# Altium schematic sheet SteppperController.SchDoc(SteppperController)
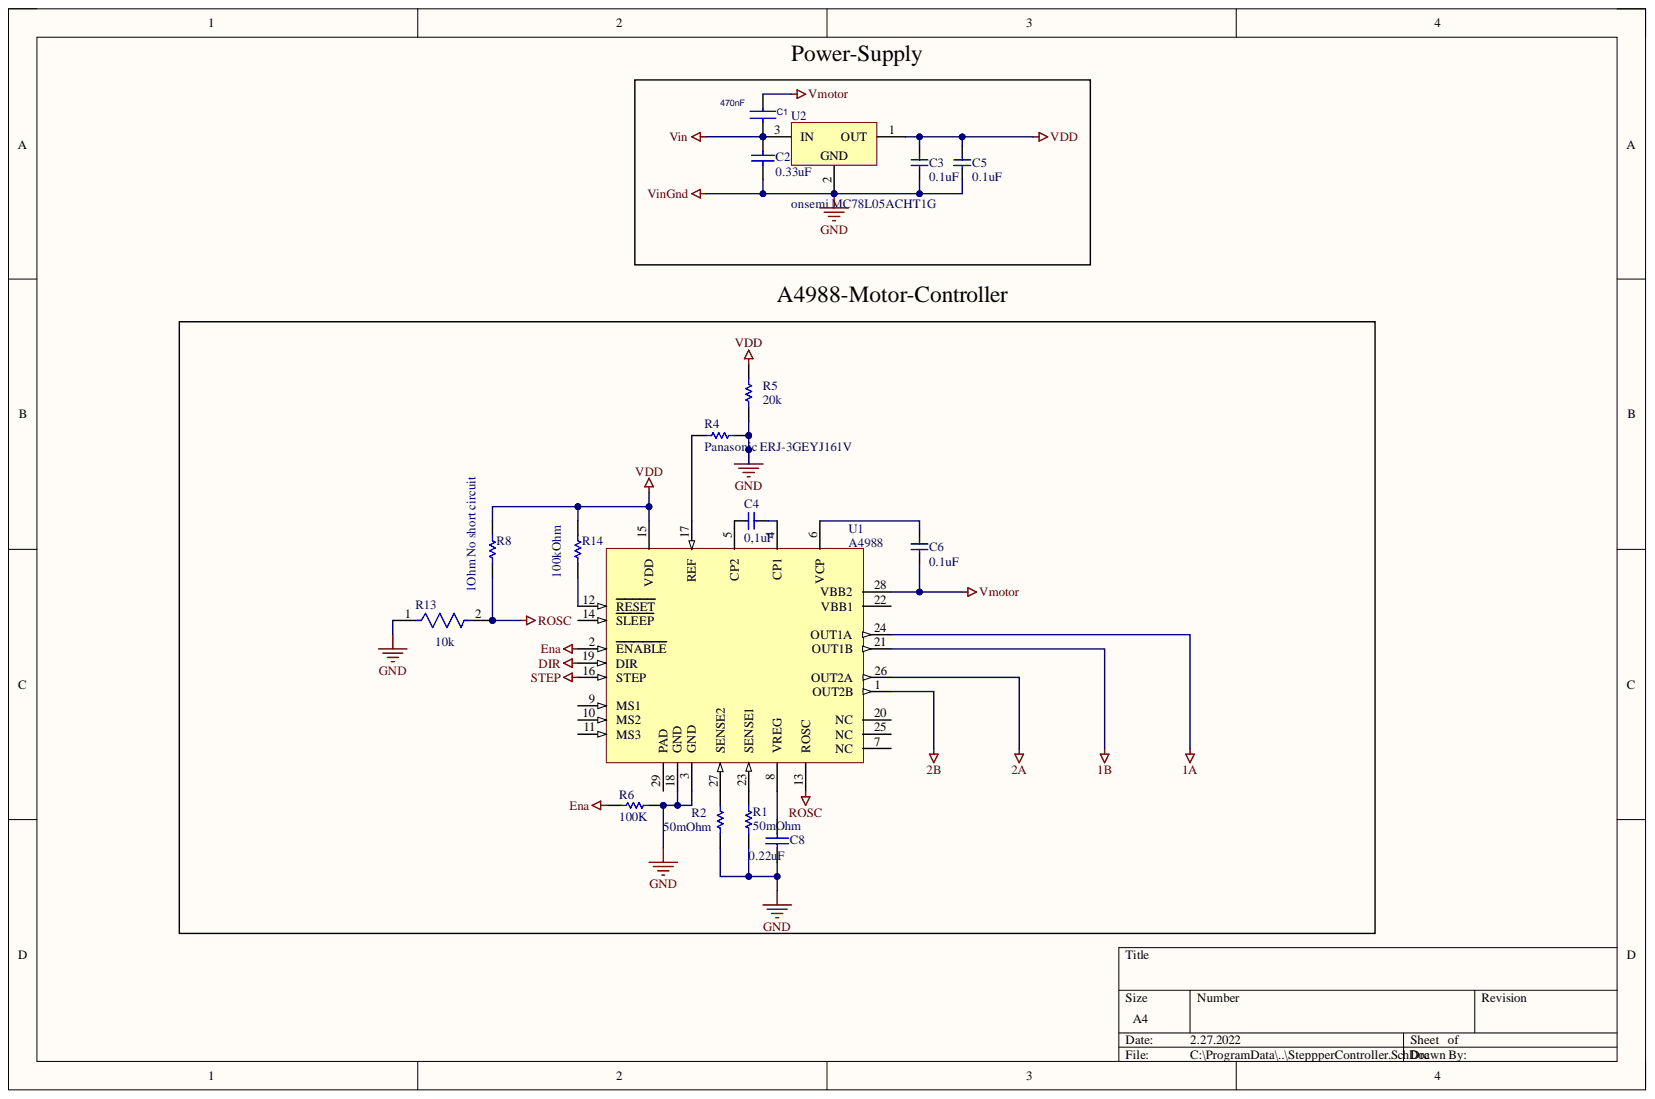
[diagram p0: SteppperController.SchDoc]

## Parts
C1  470nF  [PCB-ab16gx9rkhzdcptx7kd3-1]
C2  0.33uF  [PCB-w610zufupuummbghd1in-1]
C3  0.1uF  [PCB-lcc7kuvv5qjd2xw4yurg-1]
C4  0,1uF  [PCB-lcc7kuvv5qjd2xw4yurg-1]
C5  0.1uF  [PCB-lcc7kuvv5qjd2xw4yurg-1]
C6  0.1uF  [PCB-lcc7kuvv5qjd2xw4yurg-1]
C8  0.22uF  [PCB-pms7qjbv2zfto0v29362-1]
R1  50mOhm  [PCB-h38ewjcsmg51leo397rp-1]
R2  50mOhm  [PCB-h38ewjcsmg51leo397rp-1]
R4  Panasonic ERJ-3GEYJ161V  Manufacturer Part Number: ERJ-3GEYJ161V  [RESC1608X55X30NL15T15]
R5  20k  [RESC2013X55X40LL15T20]
R6  100K  [PCB-n97kkldbbf5rklmnt71m-1]
R8  1Ohm No short circuit  [RESC1005X40X25ML05T05]
R13  10k  [FP-CRCW0402-e3-IPC_B]
R14  100kOhm  [RESC2013X60X35ML10T20]
U1  A4988  [ALEG-ET-28_V]
U2  onsemi MC78L05ACHT1G  Manufacturer Part Number: MC78L05ACHT1G  [NXP-SOT89_A_M]

## Nets
1A: U1.24(OUT1A)
1B: U1.21(OUT1B)
2A: U1.26(OUT2A)
2B: U1.1(OUT2B)
DIR: U1.19(DIR)
Ena: R6.2 U1.2(ENABLE)
GND: C2.1 C3.1 C5.1 C8.1 R1.1 R2.1 R4.2 R5.1 R6.1 R13.1 U1.3(GND) U1.18(GND) U2.2
ROSC: R8.1 R13.2 U1.13(ROSC)
STEP: U1.16(STEP)
VDD: C3.2 C5.2 R5.2 R8.2 R14.2 U1.15(VDD) U2.1
Vin: C1.2 C2.2 U2.3
Vmotor: C1.1 C6.1 U1.28(VBB2)
NetC4_1: C4.1 U1.5(CP2)
NetC4_2: C4.2 U1.4(CP1)
NetC6_2: C6.2 U1.6(VCP)
NetC8_2: C8.2 U1.8(VREG)
NetR1_2: R1.2 U1.23(SENSE1)
NetR2_2: R2.2 U1.27(SENSE2)
NetR4_1: R4.1 U1.17(REF)
NetR14_1: R14.1 U1.12(RESET)

Not connected: U1 7(NC) 9(MS1) 10(MS2) 11(MS3) 14(SLEEP) 20(NC) 22(VBB1) 25(NC) 29(PAD)

## Library data of the parts
C1 = CMP-c29e0abbad7d1d59-2: Cap Ceramic 0.47uF 10V X5R 20% SMD 0402 85°C T/R; Manufacturer Part Number: 0402ZD474MAT2A; Voltage Rating (DC): 10.0 V; Capacitance: 470 nF; Capacitance Tolerance: ±20%; Case/Package: 0402; Component Kind: Standard; Dielectric Characteristic: X5R; Library Name: My Personal Space; Octopart URL: http://octopart.com/0402zd474mat2a-avx-19876485; OctopartID: c29e0abbad7d1d59; Packaging: Reel; RoHS: Compliant
C2 = CMP-6acba34cbf0cb50c-1: CL31 Series 0.33 uF 50 V ±10 % Tolerance X7R SMT Multilayer Ceramic Capacitor; Manufacturer Part Number: CL31B334KBFNNNE; Capacitance: 330 nF; Capacitance Tolerance: ±10%; Lead-Free Status: Lead Free; Voltage Rating (DC): 50.0 V; Case/Package: 1206; Component Kind: Standard; Dielectric Characteristic: X7R; Library Name: My Personal Space; Mounting Style: Surface Mount; Octopart URL: https://octopart.com/cl31b334kbfnnne-samsung-9302177; OctopartID: 6acba34cbf0cb50c; RoHS: Compliant
C3-C6 = CMP-915a59dc44ec4e9d-4: C Series 1206 0.1 uF 50 V ±5 % Tolerance C0G SMT Multilayer Ceramic Capacitor; Component Kind: Standard; Library Name: My Personal Space
C8 = CMP-3551434-4: Multilayer Ceramic Capacitors MLCC - SMD/SMT 50volts .22uF 5% X7R 7inch reel; Manufacturer Part Number: 08055C224JAT2A; Capacitance: 2.2e-07 F; Lead-Free Status: Lead Free; Voltage Rating (DC): 50.0 V; Capacitance Tolerance: ±5%; Case/Package: 0805; Component Kind: Standard; Dielectric Characteristic: X7R; Library Name: My Personal Space; Mounting Style: Surface Mount; Number of Pins: 2; Octopart Url: https://octopart.com/08055c224jat2a-avx+interconnect+%2F+elco-8665279; OctopartID: 61bba8357c62be7c; RoHS: Compliant
R1 R2 = CMP-2fd8e4750972f01f-1: Thick Film Surface Mnt Resistor 2512 Case 50MOhm +/-1% 1W +/-100ppm/degC; Manufacturer Part Number: CRHV2512AF50M0FKET; Component Kind: Standard; Library Name: My Personal Space; Octopart URL: http://octopart.com/crhv2512af50m0fket-vishay-44499916; OctopartID: 2fd8e4750972f01f; Packaging: Reel
R4 = CMP-3677770-2: Thick Film Resistors - SMD 0603 160ohms 5% Tol; Lead-Free Status: Lead Free; Power Rating: 0.1 W; Resistance: 160.0 Ω; Voltage Rating (DC): 75.0 V; Case/Package: 0603; Component Kind: Standard; Library Name: My Personal Space; Lifecycle Status: Not Listed by Manufacturer; Mounting Style: Surface Mount; Octopart Url: https://octopart.com/erj-3geyj161v-panasonic-39786526; OctopartID: 556f9e3a68178aa8; Packaging: Cut Tape (CT); REACH SVHC Compliance: No SVHC; Resistance Tolerance: ±5%; RoHS: Compliant; Size-Height: 0.00045 m; Size-Length: 0.0016 m; Size-Width: 0.0008 m
R5 = CMP-15323555-2: Manufacturer Part Number: TNPW080520K0BEEA; Lead-Free Status: Lead Free; Power Rating: 0.125 W; Resistance: 20000.0 Ω; Resistance Tolerance: ±0.1%; Voltage Rating (DC): 100.0 V; Case/Package: 0805; Component Kind: Standard; Library Name: My Personal Space; Number of Pins: 2; Octopart Url: https://octopart.com/tnpw080520k0beea-vishay-46507859; OctopartID: 596b92a4db5e86ac; RoHS: Compliant
R6 = CMP-86ed4a8b7141592a-1: Res General Purpose Thick Film 0402 100k Ohm 1% 1/16W ±100ppm/°C Molded Paper T/R; Manufacturer Part Number: CRGCQ0402F100K; Power Rating: 63.0 mW; Resistance: 100 kΩ; Temperature Coefficient: -100 ppm/°C to 100 ppm/°C; Voltage Rating (DC): 50.0 V; Case/Package: 0402; Component Kind: Standard; Library Name: My Personal Space; Lifecycle Status: Not Listed by Manufacturer; Octopart URL: https://octopart.com/crgcq0402f100k-te+connectivity-91017930; OctopartID: 86ed4a8b7141592a; Packaging: Tape & Reel (TR); Resistance Tolerance: ±1%; RoHS: Compliant
R8 = CMP-2002-08342-1: Manufacturer: Vishay Dale; Part Number: CRCW04021R00FKED; Component Kind: Standard; Library Name: Altium Content Vault
R13 = CMP-2002-08135-2: RES SMD 10K OHM 1% 1/16W 0402; Max Power Dissipation: 63mW; Resistance: 10kR; Temperature Coefficient: 100ppm/°C; Voltage Rating: 50V; Case Code (Imperial): 0402; Case Code (Metric): 1005; Case/Package: 0402; Component Kind: Standard; Composition: Thick Film; Contact Plating: Tin; Depth: 0.5mm; Features: Automotive AEC-Q200; Height: 0.35mm; Lead Free: Lead Free; Length: 1mm; Library Name: Altium Content Vault; Max Operating Temperature: 155°C; Military Standard: Not; Min Operating Temperature: -55°C; Mount: Surface Mount; Number of Pins: 2; Number of Terminations: 2; Package Quantity: 10; Packaging: Tape and Reel; Power Rating: 63mW; Radiation Hardening: No; Termination: SMD/SMT; Tolerance: 1%; Voltage Rating (DC): 50V; Weight: 2.3E-05oz; Width: 0.02inch
R14 = CMP-2100-03668-1: Chip Resistor, 100 KOhm, +/- 1%, 0.125 W, -55 to 155 degC, 0805 (2012 Metric), RoHS, Tape and Reel; Manufacturer: Yageo; Max Power Dissipation: 125mW; Temperature Coefficient: 100ppm/degreesC; Voltage Rating: 150V; Case Code (Imperial): 0805; Case Code (Metric): 2012; Case/Package: 0805; Component Kind: Standard; Composition: ThickFilm; Datasheet URL: http://www.yageo.com/NewPortal/yageodocoutput?fileName=/pdf/R-Chip/PYu-RC_Group_51_RoHS_L_5.pdf; Datasheet Version: Rev. 5, 10/2016; Depth: 1.25mm; Height: 0.024inch; Lead Free: LeadFree; Lead Pitch: 0.4mm; Length: 2mm; Library Name: Altium Content Vault; Manufacturer URL: http://www.yageo.com/; Max Operating Temperature: 155degC; Military Standard: Not; Min Operating Temperature: -55degC; Number of Pins: 2; Number of Terminations: 2; Package Description: 2-Pin Surface Mounted Device, Body 2 x 1.25 mm; Package Quantity: 1; Package Reference: 0805; Packaging: TapeandReel; Power Rating: 125mW; REACH SVHC: Unknown; Resistance: 100KOhm; RoHSCompliant: true; Termination: SMD/SMT; Tolerance: 1%; Voltage Rating (DC): 150V; Width: 0.049inch
U1 = CMP-966506-24: DMOS Microstepping Driver with Translator and Overcurrent Protection, 3 to 5.5 V, -20 to 85 degC, 28-Pin QFN (ET), RoHS, Tape and Reel; Component Kind: Standard; Library Name: My Personal Space
U2 = CMP-4fb3855e828b9074-8: Linear Voltage Regulator, 100 mA, 5 to 24 V, Positive Vout: 5.0 V; TJ = 0°C to +125°C; Lead-Free Status: Lead Free; Output Current: 100 mA; Component Kind: Standard; Library Name: My Personal Space; Lifecycle Status: Active; Octopart Url: https://octopart.com/mc78l05acht1g-onsemi-65529950; OctopartID: 4fb3855e828b9074; Operating Temperature: 0.00 °C (min); Packaging: Cut Tape (CT); RoHS: Compliant
